# Altium schematic sheet Peripherals.SchDoc(Peripherals)
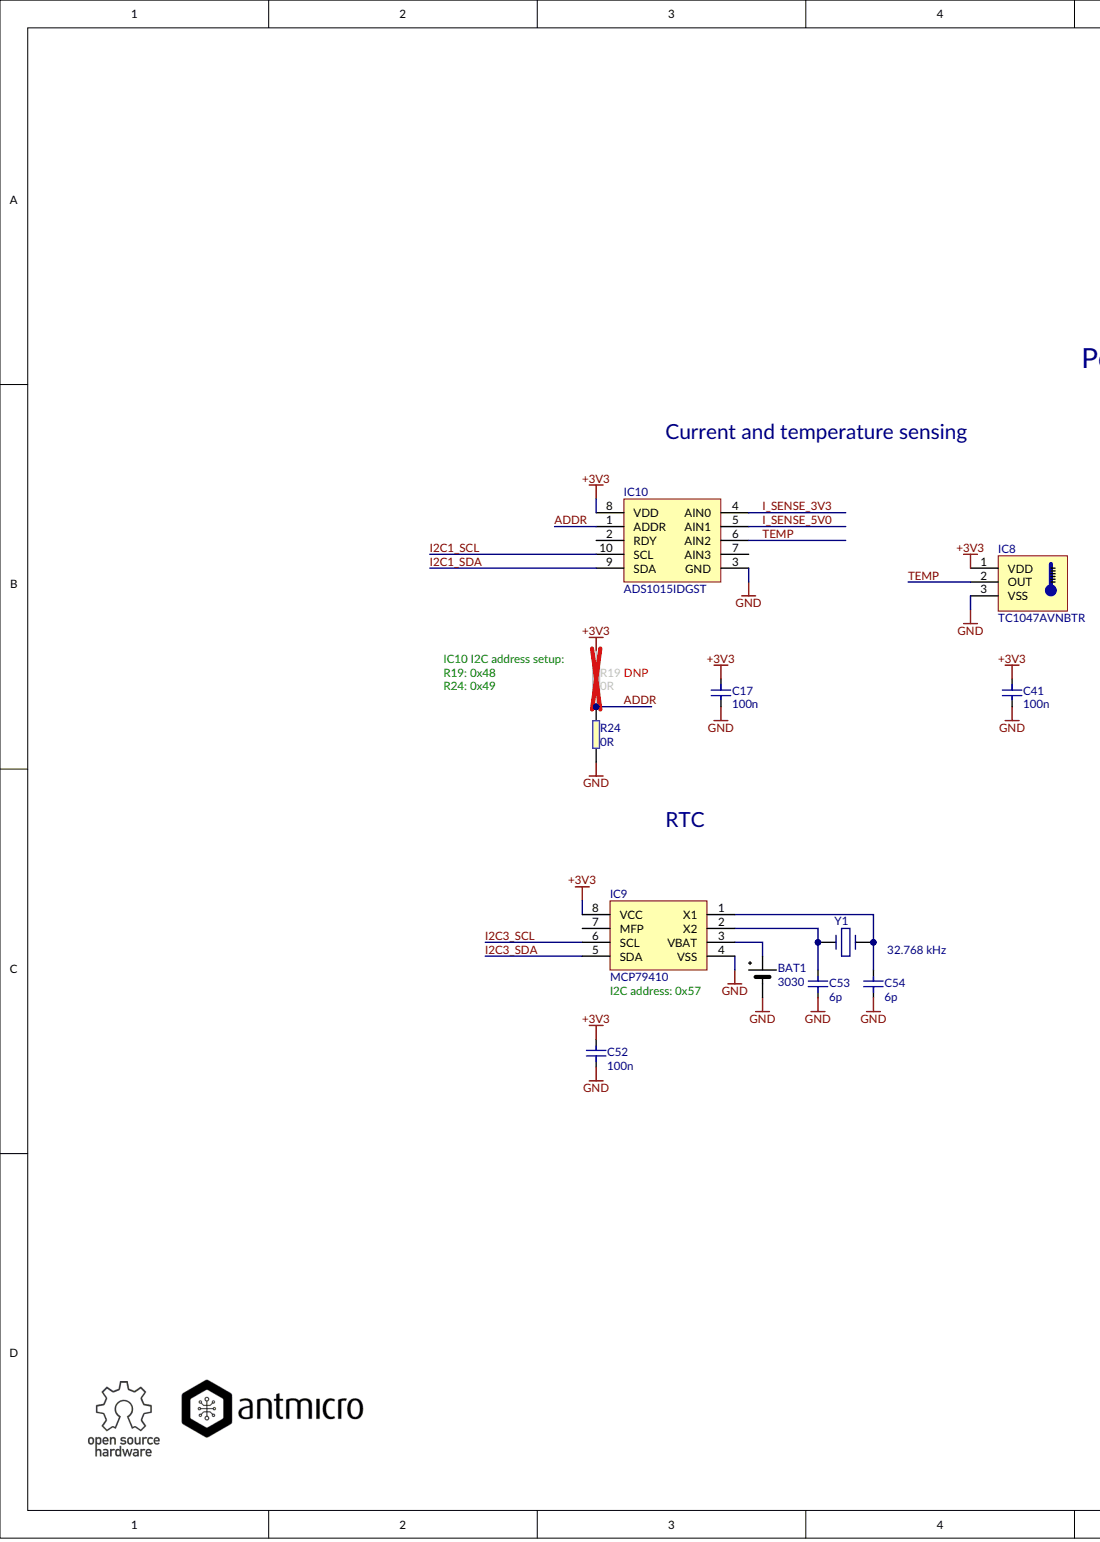
[diagram p0: Peripherals.SchDoc, left]
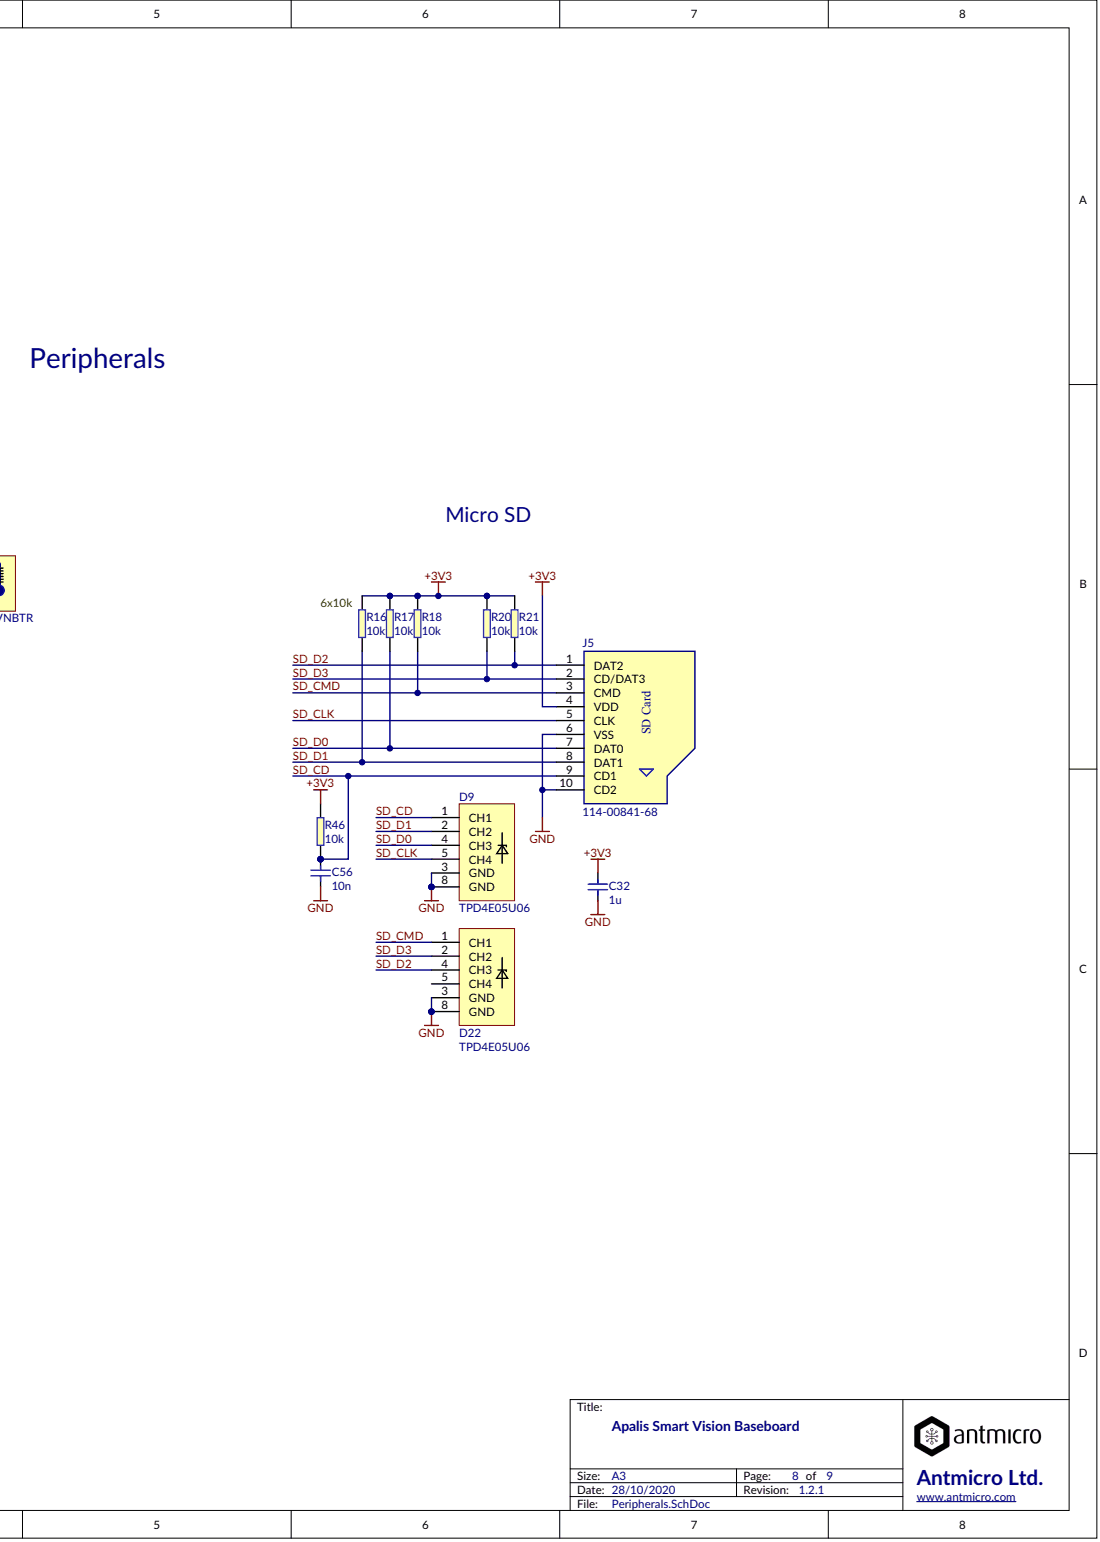
[diagram p1: Peripherals.SchDoc, right]

## Parts
BAT1  3030
C17  100n
C32  1u
C41  100n
C52  100n
C53  6p
C54  6p
C56  10n
D9  TPD4E05U06
D22  TPD4E05U06
IC8  TC1047AVNBTR
IC9  MCP79410
IC10  ADS1015IDGST
J5  114-00841-68
R16  10k
R17  10k
R18  10k
R19  0R
R20  10k
R21  10k
R24  0R
R46  10k
Y1  32.768 kHz

## Nets
+3V3: C17.1 C32.2 C41.1 C52.2 IC8.1 IC9.8(VCC) IC10.8(VDD) J5.4(VDD) R16.2 R17.2 R18.2 R19.2 R20.2 R21.2 R46.2
GND: BAT1.- C17.2 C32.1 C41.2 C52.1 C53.1 C54.1 C56.1 D9.3(GND) D9.8(GND) D22.3(GND) D22.8(GND) IC8.3 IC9.4(VSS) IC10.3(GND) J5.6(VSS) J5.10(CD2) R24.1
ADDR: IC10.1(ADDR) R19.1 R24.2
I2C1_SCL: IC10.10(SCL)
I2C1_SDA: IC10.9(SDA)
I2C3_SCL: IC9.6(SCL)
I2C3_SDA: IC9.5(SDA)
I_SENSE_3V3: IC10.4(AIN0)
I_SENSE_5V0: IC10.5(AIN1)
SD_CD: C56.2 D9.1(CH1) J5.9(CD1) R46.1
SD_CLK: D9.5(CH4) J5.5(CLK)
SD_CMD: D22.1(CH1) J5.3(CMD) R18.1
SD_D0: D9.4(CH3) J5.7(DAT0) R17.1
SD_D1: D9.2(CH2) J5.8(DAT1) R16.1
SD_D2: D22.4(CH3) J5.1(DAT2) R21.1
SD_D3: D22.2(CH2) J5.2(CD/DAT3) R20.1
TEMP: IC8.2 IC10.6(AIN2)
NetBAT1_+: BAT1.+ IC9.3(VBAT)
NetC53_2: C53.2 IC9.2(X2) Y1.2
NetC54_2: C54.2 IC9.1(X1) Y1.1

Not connected: D22 5(CH4); IC9 7(MFP); IC10 2(RDY) 7(AIN3)

## Library data of the parts
BAT1 = (no symbol name): Part Number: Keystone_CR1025; Manufacturer: Keystone; Value: 3030; Part Symbol: 3030; MPN: 3030
C17 C41 C52 = (no symbol name): Manufacturer: TDK; Part Number: C_100n_0402; Part Symbol: C1005X5R0J104K050BA; Value: 100n; MPN: C1005X5R0J104K050BA
C32 = (no symbol name): Manufacturer: MULTICOMP; Part Number: C_1u_0402; Part Symbol: MC0402F105Z6R3CT; Value: 1u; MPN: MC0402F105Z6R3CT
C53 C54 = (no symbol name): Manufacturer: WALSIN; Part Number: C_6p_0402; Part Symbol: 0402N6R0D500CT; Value: 6p; MPN: 0402N6R0D500CT
C56 = (no symbol name): Manufacturer: AVX; Part Number: C_10n_0402; Part Symbol: 04025G103ZAT2A; Value: 10n; MPN: 04025G103ZAT2A
D9 D22 = (no symbol name): Part Number: TPD4E05U06_PASS; Manufacturer: Texas Instruments; Part Symbol: TPD4E05U06DQAR; Value: TPD4E05U06; MPN: TPD4E05U06DQAR
IC8 = (no symbol name): Manufacturer: MICROCHIP; Part Number: TC1047A; Value: TC1047AVNBTR; Part Symbol: TC1047AVNBTR; MPN: TC1047AVNBTR
IC9 = (no symbol name): Manufacturer: MICROCHIP; Part Symbol: MCP79410-I/SN; Part Number: MCP79410; Value: MCP79410; MPN: MCP79410-I/SN
IC10 = (no symbol name): Manufacturer: TEXAS INSTRUMENTS; Part Number: ADS1015IDGST; Value: ADS1015IDGST; Part Symbol: ADS1015IDGST; MPN: ADS1015IDGST
J5 = (no symbol name): Part Number: AMP-114-00841-68; Manufacturer: AMPHENOL COMMERCIAL PRODUCTS; Value: 114-00841-68; Part Symbol: 114-00841-68; MPN: 114-00841-68
R16-R18 R20 R21 R46 = (no symbol name): Manufacturer: MULTICOMP; Part Number: R_10k_0402; Part Symbol: MCMR04X1002FTL; Value: 10k; MPN: MCMR04X1002FTL
R19 R24 = (no symbol name): Manufacturer: BOURNS; Part Number: R_0R_0603; Part Symbol: CR0603-J/-000ELF; Value: 0R; MPN: CR0603-J/-000ELF
Y1 = (no symbol name): Part Number: ABS25-32.768KHZ-6-T; Manufacturer: ABRACON; Value: 32.768 kHz; Part Symbol: ABS25-32.768KHZ-6-T; MPN: ABS25-32.768KHZ-6-T
